# Altium schematic sheet 1_CONNECTORS.SchDoc(1_CONNECTORS)
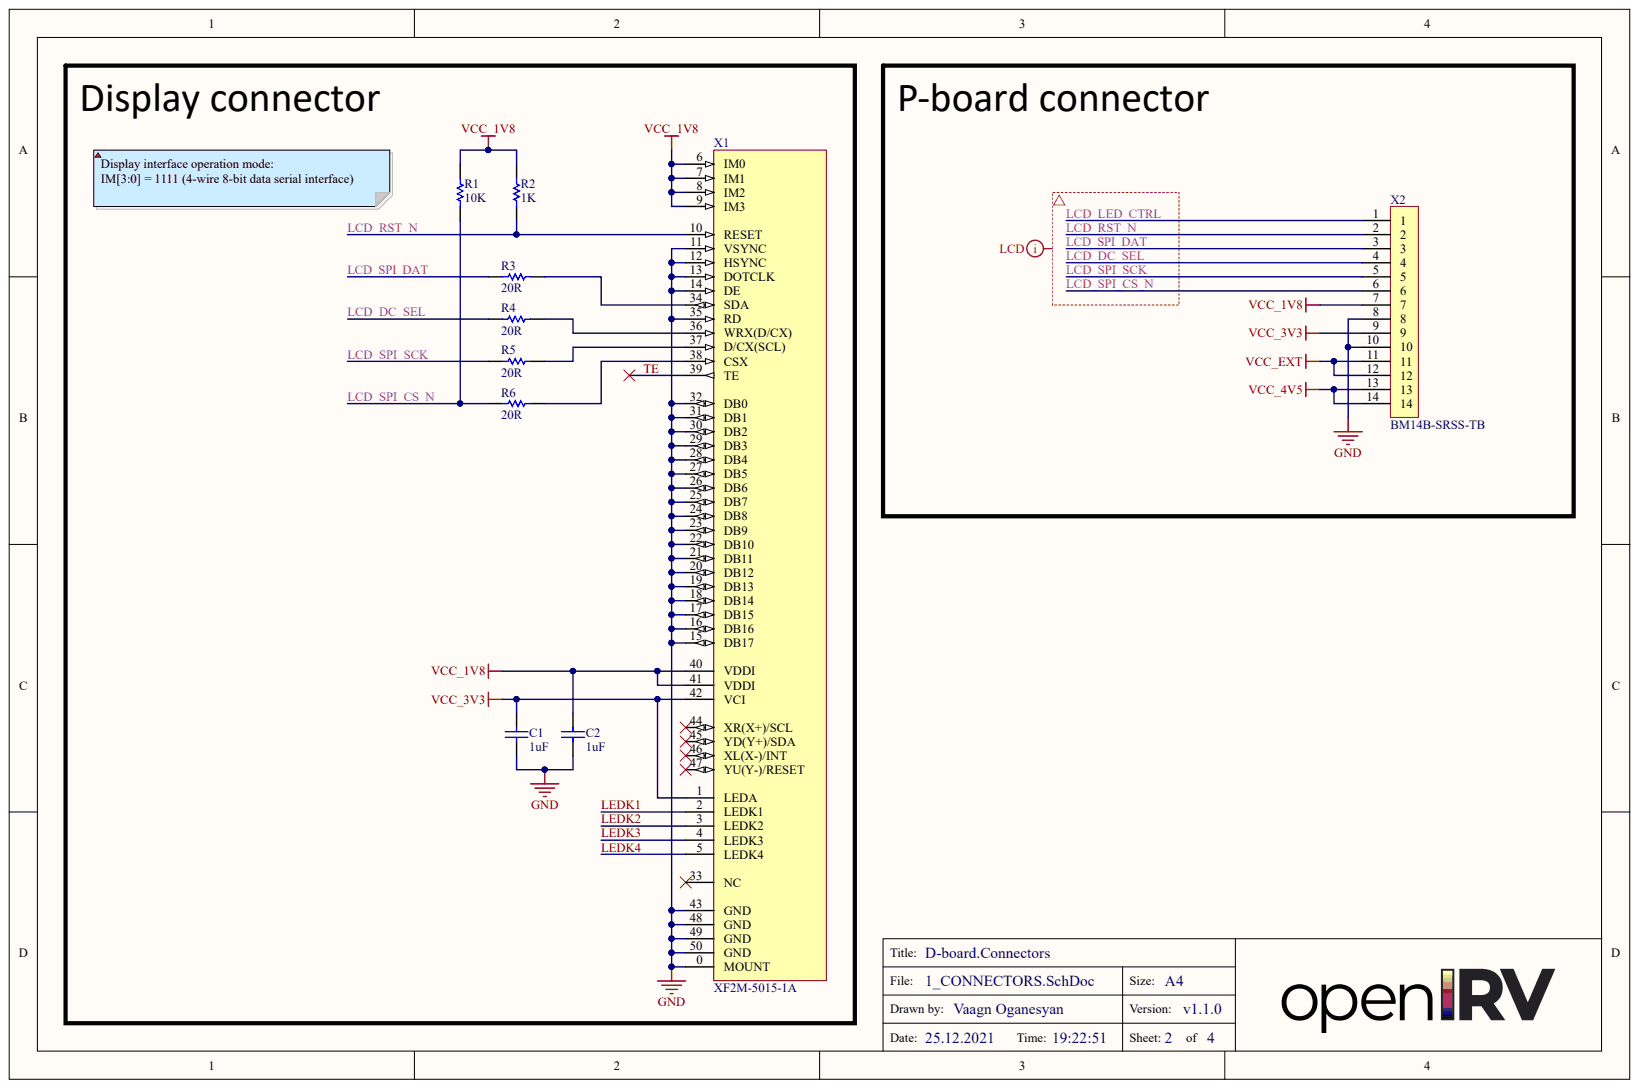
[diagram p0: 1_CONNECTORS.SchDoc]

## Parts
C1  1uF  [C0603[1608]]
C2  1uF  [C0603[1608]]
R1  10K  [R0402[1005]]
R2  1K  [R0402[1005]]
R3  20R  [R0402[1005]]
R4  20R  [R0402[1005]]
R5  20R  [R0402[1005]]
R6  20R  [R0402[1005]]
X1  XF2M-5015-1A  [XF2M-5015-1A]
X2  BM14B-SRSS-TB  [BM14B-SRSS-TB]

## Nets
GND: C1.1 C2.1 X1.0(MOUNT) X1.11(VSYNC) X1.12(HSYNC) X1.13(DOTCLK) X1.14(DE) X1.15(DB17) X1.16(DB16) X1.17(DB15) X1.18(DB14) X1.19(DB13) X1.20(DB12) X1.21(DB11) X1.22(DB10) X1.23(DB9) X1.24(DB8) X1.25(DB7) X1.26(DB6) X1.27(DB5) X1.28(DB4) X1.29(DB3) X1.30(DB2) X1.31(DB1) X1.32(DB0) X1.35(RD) X1.43(GND) X1.48(GND) X1.49(GND) X1.50(GND) X2.8 X2.10
VCC_1V8: C2.2 R1.2 R2.2 X1.6(IM0) X1.7(IM1) X1.8(IM2) X1.9(IM3) X1.40(VDDI) X1.41(VDDI) X2.7
VCC_3V3: C1.2 X1.1(LEDA) X1.42(VCI) X2.9
VCC_4V5: X2.13 X2.14
VCC_EXT: X2.11 X2.12
LCD_DC_SEL: R4.1 X2.4
LCD_LED_CTRL: X2.1
LCD_RST_N: R2.1 X1.10(RESET) X2.2
LCD_SPI_CS_N: R1.1 R6.1 X2.6
LCD_SPI_DAT: R3.1 X2.3
LCD_SPI_SCK: R5.1 X2.5
LEDK1: X1.2(LEDK1)
LEDK2: X1.3(LEDK2)
LEDK3: X1.4(LEDK3)
LEDK4: X1.5(LEDK4)
TE: X1.39(TE)
NetR3_2: R3.2 X1.34(SDA)
NetR4_2: R4.2 X1.36(WRX(D/CX))
NetR5_2: R5.2 X1.37(D/CX(SCL))
NetR6_2: R6.2 X1.38(CSX)
N00021:

Not connected: X1 33(NC) 44(XR(X+)/SCL) 45(YD(Y+)/SDA) 46(XL(X-)/INT) 47(YU(Y-)/RESET)

## Library data of the parts
C1 C2 = CAP: Dielectric: X7R; Tolerance: 10%; Voltage: 25v; Component Kind: Standard; Library Name: general.IntLib; Mounting: SMD; Value: 1uF
R1-R6 = RES: Power: 0.06W; Tolerance: 5%; Component Kind: Standard; Library Name: general.IntLib; Mounting: SMD
  R1: Value: 10K
  R2: Value: 1K
  R3-R6: Value: 20R
X1 = XF2M-5015-1A: ER-TFT023-1 TFT LCD Display 2.3"; Component Kind: Standard; Library Name: general.IntLib
X2 = BM14B-SRSS-TB: Component Kind: Standard; Library Name: general.IntLib
